# Altium schematic sheet HELIALights2022V1.0Sheet1.SchDoc(HELIALights2022V1.0Sheet1)
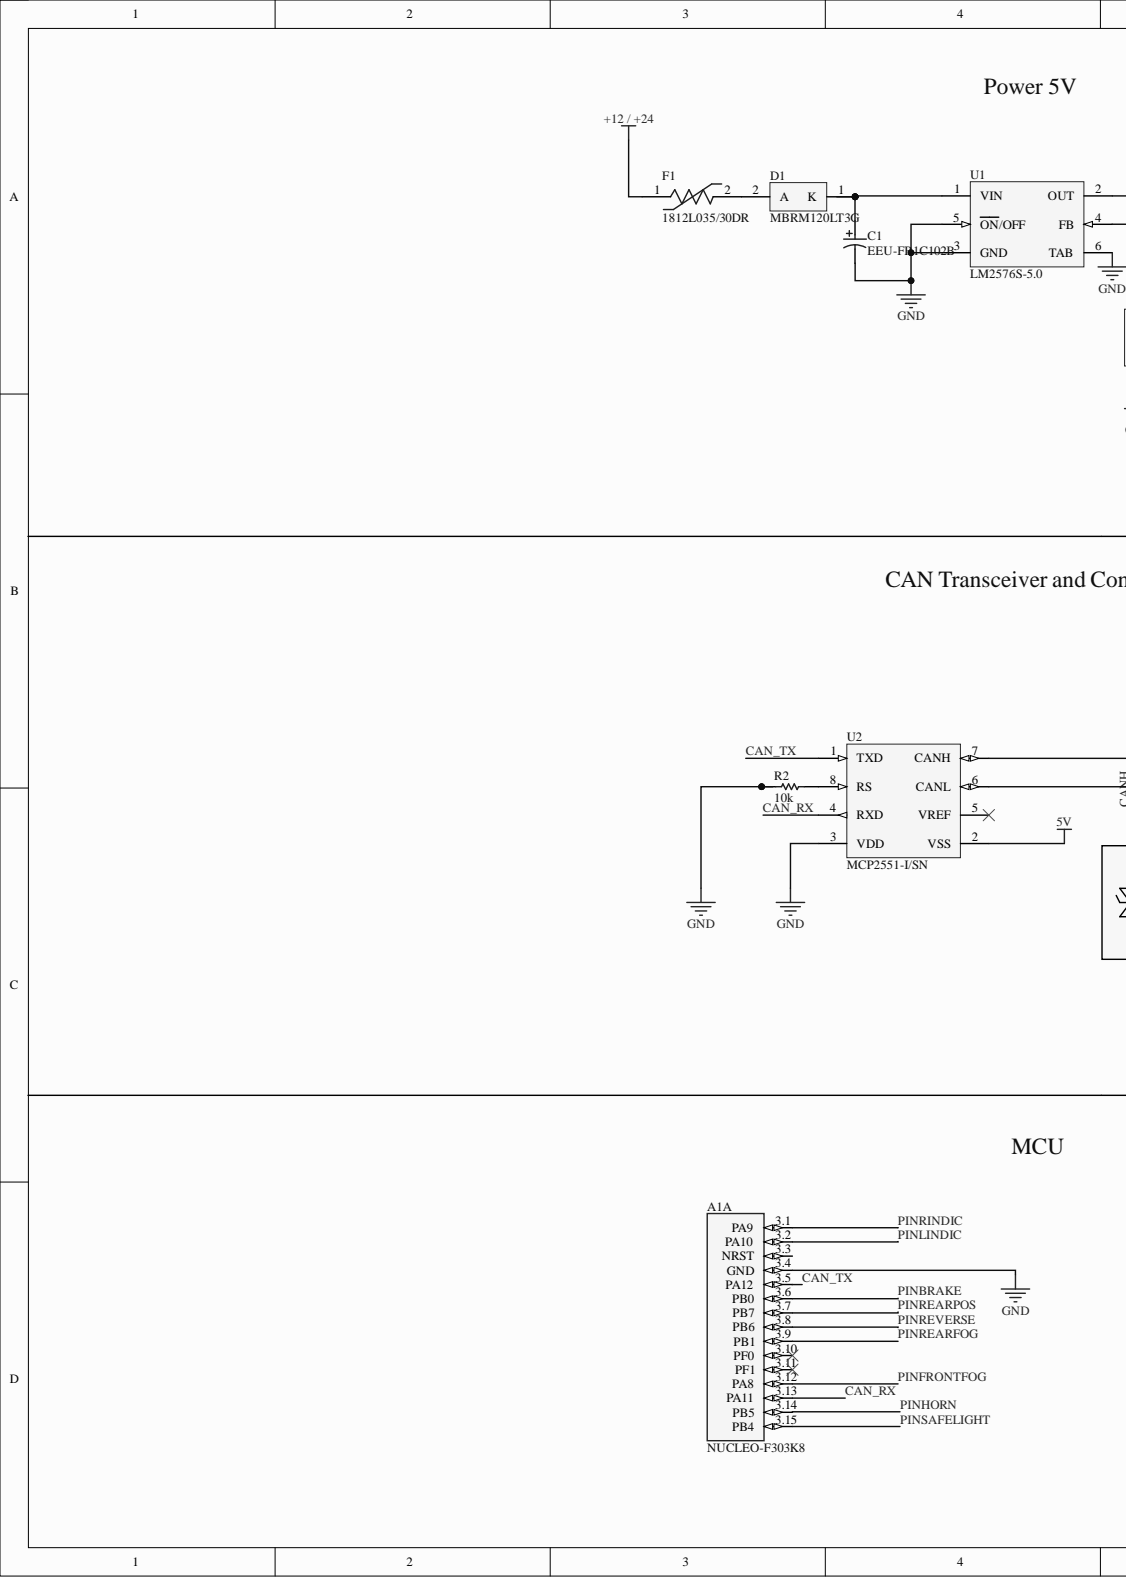
[diagram p0: HELIALights2022V1.0Sheet1.SchDoc, left]
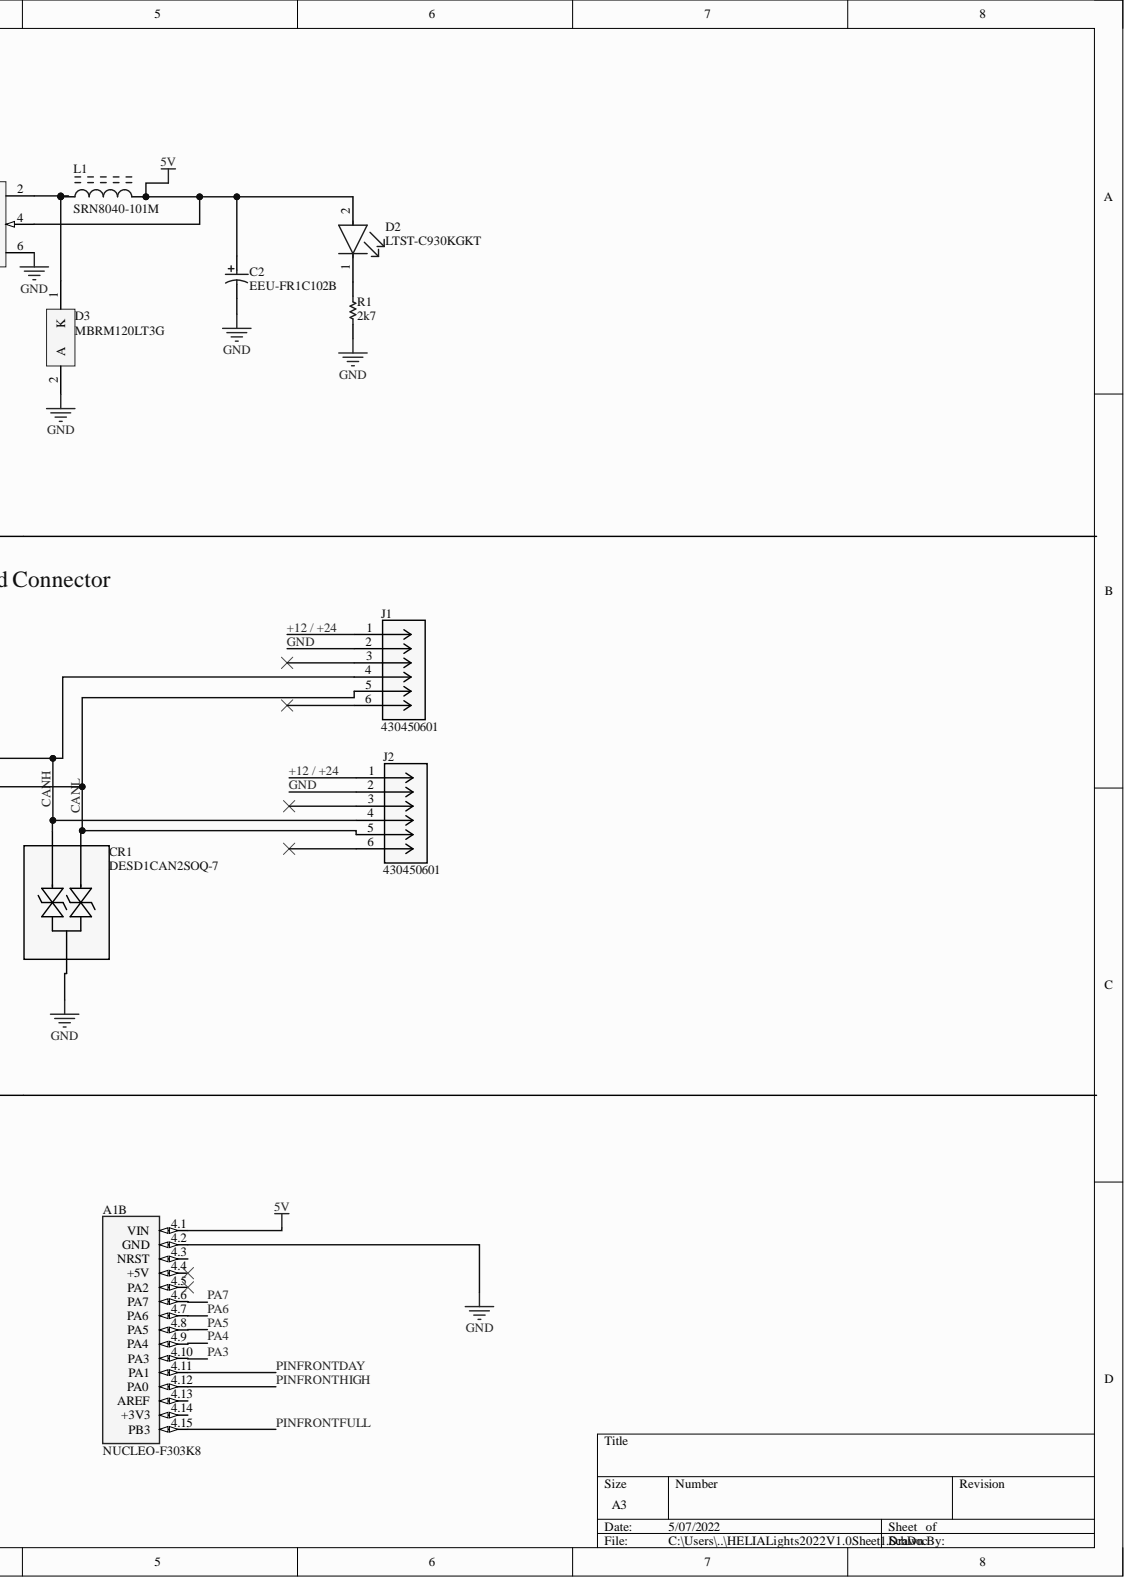
[diagram p1: HELIALights2022V1.0Sheet1.SchDoc, right]

## Parts
A1  NUCLEO-F303K8  [MODULE_NUCLEO-F303K8]  (parts A,B)
C1  EEU-FR1C102B  [PNSC-EEUFM1H221-2]
C2  EEU-FR1C102B  [PNSC-EEUFM1H221-2]
CR1  DESD1CAN2SOQ-7  [DIODE3_DESD1CAN2SOQ-7_DIO]
D1  MBRM120LT3G  [ONSC-POWERMITE-2-457-04_D_V]
D2  LTST-C930KGKT  [FP-LTST-C930KGKT-MFG]
D3  MBRM120LT3G  [ONSC-POWERMITE-2-457-04_D_V]
F1  1812L035/30DR  [FP-1812L035-30DR-MFG]
J1  430450601  [CON_430450601_MOL]
J2  430450601  [CON_430450601_MOL]
L1  SRN8040-101M  [BOUR-SRN8040-101M_V]
R1  2k7  [RESC1609X50X30NL8T20]
R2  10k  [RESC1609X50X30NL8T20]
U1  LM2576S-5.0  [KTT0005B_N]
U2  MCP2551-I/SN  [MCHP-SN8_V]

## Nets
5V: A1.4.1(VIN) C2.1 D2.2 L1.2 U1.4(FB) U2.2(VSS)
+12 / +24: F1.1 J1.1 J2.1
CAN_RX: A1.3.13(PA11) U2.4(RXD)
CAN_TX: A1.3.5(PA12) U2.1(TXD)
CANH: CR1.2 J1.4 J2.4 U2.7(CANH)
CANL: CR1.1 J1.5 J2.5 U2.6(CANL)
GND: A1.3.4(GND) A1.4.2(GND) C1.2 C2.2 CR1.3 D3.2 J1.2 J2.2 R1.1 R2.2 U1.3(GND) U1.5(ON/OFF) U1.6(TAB) U2.3(VDD)
PA3: A1.4.10(PA3)
PA4: A1.4.9(PA4)
PA5: A1.4.8(PA5)
PA6: A1.4.7(PA6)
PA7: A1.4.6(PA7)
PINBRAKE: A1.3.6(PB0)
PINFRONTDAY: A1.4.11(PA1)
PINFRONTFOG: A1.3.12(PA8)
PINFRONTFULL: A1.4.15(PB3)
PINFRONTHIGH: A1.4.12(PA0)
PINHORN: A1.3.14(PB5)
PINLINDIC: A1.3.2(PA10)
PINREARFOG: A1.3.9(PB1)
PINREARPOS: A1.3.7(PB7)
PINREVERSE: A1.3.8(PB6)
PINRINDIC: A1.3.1(PA9)
PINSAFELIGHT: A1.3.15(PB4)
NetC1_1: C1.1 D1.1 U1.1(VIN)
NetD1_2: D1.2 F1.2
NetD2_1: D2.1 R1.2
NetD3_1: D3.1 L1.1 U1.2(OUT)
NetR2_1: R2.1 U2.8(RS)

Not connected: A1 3.3(NRST) 3.10(PF0) 3.11(PF1) 4.3(NRST) 4.4(+5V) 4.5(PA2) 4.13(AREF) 4.14(+3V3); J1 3 6; J2 3 6; U2 5(VREF)

## Library data of the parts
A1 = NUCLEO-F303K8: STM32F303K8, mbed-Enabled Development Nucleo-32 STM32F3 ARMÂ® CortexÂ®-M4 MCU 32-Bit Embedded Evaluation Board; MF: STMicroelectronics; Availability: Unavailable; Component Kind: Standard; Library Name: NUCLEO-F303K8.IntLib; MP: NUCLEO-F303K8; Package: None; Price: None; SnapEDA_Link: https://www.snapeda.com/parts/NUCLEO-F303K8/STMicroelectronics/view-part/276791/?ref=snap
C1 C2 = CMP-2000-05313-1: Aluminum Electrolytic Capacitor, 1000 uF, +/- 20%, 16 V, -40 to 105 degC, 2-Pin THD, RoHS, Tape and Reel; Manufacturer: Panasonic; Capacitance: 1000µF; Impedance: 0.028O; Lifetime@Temperature: 8000Hrs@105degC; Rated Voltage: 16V; Ripple Current: 1790mA; Type: Radial; Case Size/Dimension: Ø10x16mm; Component Kind: Standard; Datasheet URL: http://www.produktinfo.conrad.com/datenblaetter/425000-449999/446746-da-01-en-ALU_KONDENSATOR_FR_R_1000_UF_16V.pdf; Datasheet Version: Nov-11; Library Name: Altium Content Vault; Manufacturer URL: http://www.panasonic.com/; Operating Temp Range: -40to+105degC; Operating Temp-Max: 105degC; Series: FR
CR1 = DESD1CAN2SOQ-7: No Description Available; Mfr_Name: Diodes Inc; Type: DEV; Component Kind: Standard; Library Name: DESD1CAN2SOQ-7.SchLib; Manufacturer_Part_Number: DESD1CAN2SOQ-7; RefDes: RefDes; Copyright: Copyright (C) 2022 Ultra Librarian. All rights reserved.
D1 D3 = CMP-1055-00263-1: Schottky Power Rectifier, 2-Pin Powermite, Pb-Free, Tape and Reel; Manufacturer: On Semiconductor; PartNumber: MBRM120LT1G; Configuration: Single; IFSM Max (A): 50; Component Kind: Standard; ComponentLink1Description: Manufacturer URL; ComponentLink1URL: http://www.onsemi.com/; ComponentLink2Description: Datasheet; ComponentLink2URL: http://www.onsemi.cn/pub_link/Collateral/MBRM120L-D.PDF; ComponentLink3Description: Package Specification; ComponentLink3URL: http://www.onsemi.com/pub_link/Collateral/457-04.PDF; DatasheetVersion: Rev. 7; Library Name: Altium Content Vault; Mounting Technology: Surface Mount; PackageDescription: 2-Pin POWERMITE, Body 1.965 x 1.9 mm; PackageReference: POWERMITE-2-457-04; PackageVersion: Rev. F, 05/2013; Packing: Tape and Reel; RoHS: TRUE
D2 = CMP-2000-06626-2: LED GREEN CLEAR CHIP SMD; Dominant Wavelength: 571nm; Forward Current: 30mA; Forward Voltage: 2V; Lens Color: Colorless; Lens Style: Diffused; Luminous Intensity: 600mcd; Number of Elements: 1; Power Dissipation: 75mW; Reverse Voltage: 5V; Test Current: 20mA; Viewing Angle: 25°; Wavelength: 574nm; Case Code (Imperial): 1209; Case Code (Metric): 3224; Case/Package: 1209; Color: Green; Component Kind: Standard; Depth: 2.4mm; Height: 2.4mm; Illumination Color: Green; Lead Free: Lead Free; Length: 3.2mm; Lens Transparency: Clear; Library Name: Altium Content Vault; Max Operating Temperature: 85°C; Min Operating Temperature: -55°C; Mount: Surface Mount; Number of LEDs: 1; Number of Pins: 2; Package Quantity: 1; Packaging: Tape and Reel; Power Rating: 75mW; Radiation Hardening: No; RoHS Compliant: Yes; Series: -; Voltage: 5V; Width: 2.4mm
F1 = CMP-08606-000005-1: PTC RESET FUSE 30V 350MA 1812; Manufacturer: Littelfuse Inc; Current Rating: 40A; Hold Current: 0.35A; Resistance: 0.4-1.7Ω; Trip Current: 0.75A; Trip Time: 0.15s; Value: 0.4-1.7Ω; Ambient Temperature Range High: +85°C; Ambient Temperature Range Low: -40°C; Automotive: No; Category: Fuse; Component Kind: Standard; ComponentLink2Description: Datasheet; ComponentLink2URL: https://www.littelfuse.com/~/media/electronics/datasheets/resettable_ptcs/littelfuse_ptc_1812l_datasheet.pdf.pdf; Device Class L1: Circuit Protection; Device Class L2: PTC Resettable Fuses; Device Class L3: unset; Digikey Description: PTC RESET FUSE 30V 350MA 1812; Height: 1.25mm; Lead Free: Yes; Library Name: Altium Content Vault; Manufacturer Part Number: 1812L035/30DR; Mouser Description: Resettable Fuses - PPTC PTC 30V POLY 1812 HALOGEN-FREE .35A; Octopart: https://octopart.com/1812l035%2F30dr-littelfuse-19645438; Package: 0; RoHS: TRUE; Temperature: -40°C to +85°C; Temperature Range High: +85°C; Temperature Range Low: -40°C; Voltage Rating: 30V
J1 J2 = 430450601: No Description Available; Mfr_Name: Molex Connector Corporation; Component Kind: Standard; Library Name: 430450601.SchLib; Manufacturer_Part_Number: 430450601; RefDes: RefDes; Type: DEV; Copyright: Copyright (C) 2022 Ultra Librarian. All rights reserved.
L1 = CMP-2000-07143-1: Semi-Shielded Power Inductor, 100 uH, -40 to 125 degC, 2-Pin SMD, RoHS, Tape and Reel; Manufacturer: Bourns; Brand/Series: SRN8040Series; Current, Rating: 1    A; Inductance: 100    µH; Tolerance: ±20    %; Component Kind: Standard; Datasheet URL: http://www.produktinfo.conrad.com/datenblaetter/1000000-1099999/001056456-da-01-en-MAG_ABG_LEIST_IND_SRN8040_101M_100UH_SMD.pdf; Datasheet Version: 08/2013; Library Name: Altium Content Vault; Manufacturer URL: http://www.bourns.com/; Mounting Technology: SurfaceMount; Package Description: 2-Pin Surface Mount Device, Body 8 x 8 mm; Package Reference: SRN8040-101M; Termination: SMT; Type: Power
R1 R2 = CMP-2000-03816-5: Chip Resistor, 0 Ohm, Jumper, 100 mW, -55 to 155 degC, 0603 (1608 Metric), RoHS, Tape and Reel; Manufacturer: Vishay Semiconductor; Voltage Rating: 75 V; Component Kind: Standard; ComponentLink1Description: Manufacturer URL; ComponentLink1URL: http://www.vishay.com/; ComponentLink2Description: Datasheet; ComponentLink2URL: http://www.vishay.com/docs/20035/dcrcwe3.pdf; DatasheetVersion: 06/2012; Height: 0.45 mm; Length: 1.55 mm; Library Name: Altium Content Vault; Max Operating Temperature: 155 degC; Min Operating Temperature: -55 degC; Mount: Surface Mount; Mounting Technology: Surface Mount; Package Quantity: 5000; PackageDescription: 2-Pin Surface Mount Device, Body 1.575 x 0.85 mm; PackageReference: 0603; Packaging: Tape and Reel; Pins: 2; REACH SVHC: Unknown; RoHSCompliant: true
U1 = CMP-0069-00115-3: SIMPLE SWITCHER® 3A Step-Down Voltage Regulator, 5-pin TO-263; Manufacturer: Texas Instruments; PackageTopMarking: NSUZXYTTE#*LM2576S*-5.0 P+; PowerWise: N; Code_JEDEC: MO-169-AB; Component Kind: Standard; ComponentLink1Description: Datasheet URL; ComponentLink1URL: http://www.ti.com/general/docs/lit/getliterature.tsp?genericPartNumber=LM2576&fileType=pdf; CompType: Switcher; Library Name: Altium Content Vault; PackageDescription: TO-263, Molded, .400 x .390 x .180in, 5 Lead, .067in Pitch, Surface Mount; PackageReference: KTT0005B; PinCount: 5; RoHS: N
U2 = CMP-0186-00138-3: High-Speed CAN Transceiver,   1 Mb/s, 4.5 to 5.5 V, 8-Pin SOIC (SN), Industrial Temperature, RoHS, Tube; Manufacturer: Microchip; Component Kind: Standard; ComponentLink1Description: Manufacturer URL; ComponentLink1URL: http://www.microchip.com/; ComponentLink2Description: Datasheet; ComponentLink2URL: http://ww1.microchip.com/downloads/en/DeviceDoc/21667f.pdf; ComponentLink3Description: Package Specification; ComponentLink3URL: http://www.microchip.com/stellent/groups/techpub_sg/documents/packagingspec/en012702.pdf; DatasheetVersion: Rev. F, 07/2010; Library Name: Altium Content Vault; Mounting Technology: Surface Mount; PackageDescription: 8-Pin Small Outline Integrated Circuit, Body 4.9 x 3.9 mm, Pitch 1.27 mm; PackageReference: SN8; RoHSCompliant: true
